# Altium schematic sheet LiDo_uC_Board_Controller.SchDoc
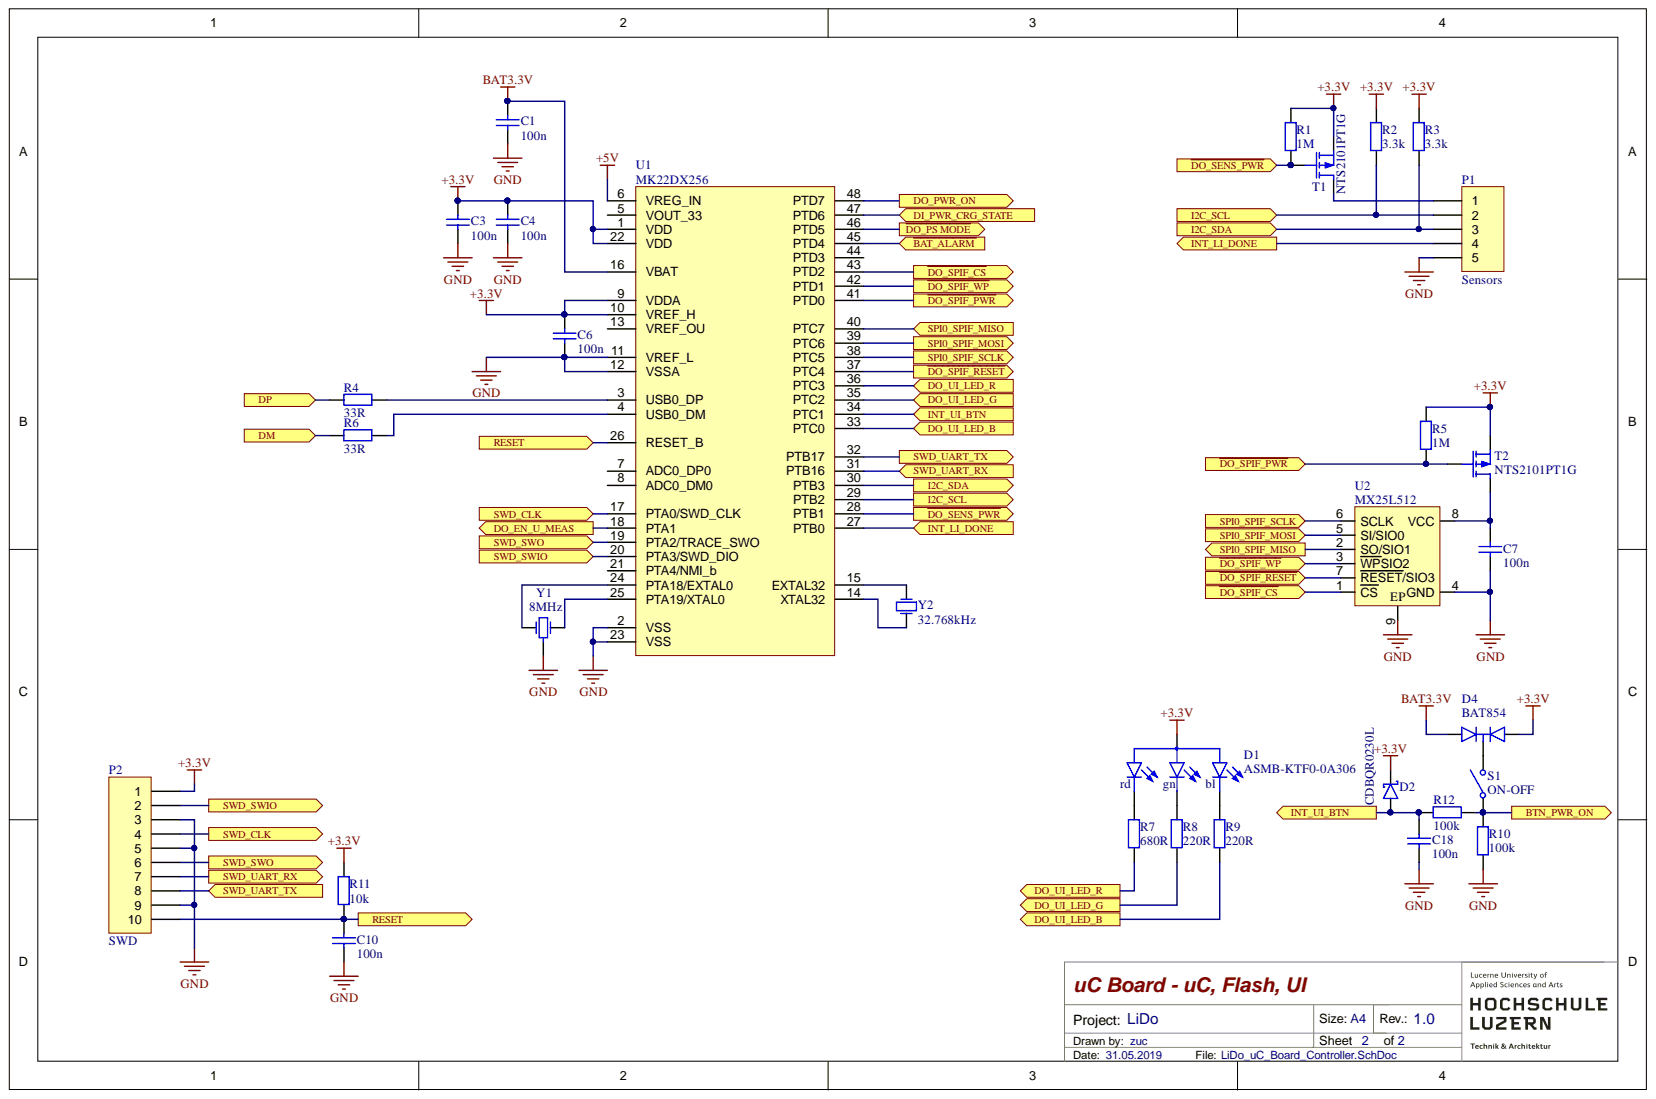
[diagram p0: LiDo_uC_Board_Controller.SchDoc]

## Parts
C1  100n  [0402S]
C3  100n  [0402S]
C4  100n  [0402S]
C6  100n  [0402S]
C7  100n  [0402S]
C10  100n  [0402S]
C18  100n  [0402S]
D1  ASMB-KTF0-0A306  [4PLCC]
D2  CDBQR0230L  [0402d]
D4  BAT854  [SOT-323]
P1  Sensors  [HDR1X5]
P2  SWD  [HEADER2X5_1.27S]
R1  1M  [0402S]
R2  3.3k  [0402S]
R3  3.3k  [0402S]
R4  33R  [0402S]
R5  1M  [0402S]
R6  33R  [0402S]
R7  680R  [0402S]
R8  220R  [0402S]
R9  220R  [0402S]
R10  100k  [0402S]
R11  10k  [0402S]
R12  100k  [0402S]
S1  ON-OFF  [TL3315]
T1  NTS2101PT1G  [SOT-323]
T2  NTS2101PT1G  [SOT-323]
U1  MK22DX256  [QFP50P700X700X160-48N]
U2  MX25L512  [WSON8L]
Y1  8MHz  [CSTCE-G15L]
Y2  32.768kHz  [ABS06]

## Ports
BTN_PWR_ON, DI_PWR_CRG_STATE, DM, DO_EN_U_MEAS, DO_PWR_ON, DO_UI_LED_B, DO_UI_LED_G, DO_UI_LED_R, DP, I2C_SCL, I2C_SDA, INT_LI_DONE, INT_UI_BTN, RESET, SPI0_SPIF_MISO, SPI0_SPIF_MOSI, SPI0_SPIF_SCLK, SWD_CLK, SWD_SWIO, SWD_SWO, SWD_UART_RX, SWD_UART_TX, ~{BAT_ALARM}, ~{DO_PS MODE}, ~{DO_SENS_PWR}, ~{DO_SPIF_CS}, ~{DO_SPIF_PWR}, ~{DO_SPIF_RESET}, ~{DO_SPIF_WP}

## Nets
+3.3V: C3.2 C4.2 C6.2 D1.1 D2.2 D4.1 P2.1 R1.2 R2.2 R3.2 R5.2 R11.2 T1.3 T2.3 U1.1(VDD) U1.9(VDDA) U1.10(VREF_H) U1.22(VDD)
+5V: U1.6(VREG_IN)
BAT3.3V: C1.2 D4.2 U1.16(VBAT)
GND: C1.1 C3.1 C4.1 C6.1 C7.1 C10.1 C18.1 P1.5 P2.3 P2.5 P2.9 R10.1 U1.2(VSS) U1.11(VREF_L) U1.12(VSSA) U1.23(VSS) U2.4(GND) U2.9(EP) Y1.2
~{BAT_ALARM}: U1.45(PTD4) ; port ~{BAT_ALARM}
BTN_PWR_ON: R10.2 R12.1 S1.1 ; port BTN_PWR_ON
~{DO_PS MODE}: U1.46(PTD5) ; port ~{DO_PS MODE}
~{DO_SENS_PWR}: R1.1 T1.2 U1.28(PTB1) ; port ~{DO_SENS_PWR}
~{DO_SPIF_CS}: U1.43(PTD2) U2.1(CS) ; port ~{DO_SPIF_CS}
~{DO_SPIF_PWR}: R5.1 T2.2 U1.41(PTD0) ; port ~{DO_SPIF_PWR}
~{DO_SPIF_RESET}: U1.37(PTC4) U2.7(RESET/SIO3) ; port ~{DO_SPIF_RESET}
~{DO_SPIF_WP}: U1.42(PTD1) U2.3(WPSIO2) ; port ~{DO_SPIF_WP}
DI_PWR_CRG_STATE: U1.47(PTD6) ; port DI_PWR_CRG_STATE
DM: R6.2 ; port DM
DO_EN_U_MEAS: U1.18(PTA1) ; port DO_EN_U_MEAS
DO_PWR_ON: U1.48(PTD7) ; port DO_PWR_ON
DO_UI_LED_B: R9.1 U1.33(PTC0) ; port DO_UI_LED_B
DO_UI_LED_G: R8.1 U1.35(PTC2) ; port DO_UI_LED_G
DO_UI_LED_R: R7.1 U1.36(PTC3) ; port DO_UI_LED_R
DP: R4.2 ; port DP
I2C_SCL: P1.2 R2.1 U1.29(PTB2) ; port I2C_SCL
I2C_SDA: P1.3 R3.1 U1.30(PTB3) ; port I2C_SDA
INT_LI_DONE: P1.4 U1.27(PTB0) ; port INT_LI_DONE
INT_UI_BTN: C18.2 D2.1 R12.2 U1.34(PTC1) ; port INT_UI_BTN
RESET: C10.2 P2.10 R11.1 U1.26(RESET_B) ; port RESET
SPI0_SPIF_MISO: U1.40(PTC7) U2.2(SO/SIO1) ; port SPI0_SPIF_MISO
SPI0_SPIF_MOSI: U1.39(PTC6) U2.5(SI/SIO0) ; port SPI0_SPIF_MOSI
SPI0_SPIF_SCLK: U1.38(PTC5) U2.6(SCLK) ; port SPI0_SPIF_SCLK
SWD_CLK: P2.4 U1.17(PTA0/SWD_CLK) ; port SWD_CLK
SWD_SWIO: P2.2 U1.20(PTA3/SWD_DIO) ; port SWD_SWIO
SWD_SWO: P2.6 U1.19(PTA2/TRACE_SWO) ; port SWD_SWO
SWD_UART_RX: P2.7 U1.31(PTB16) ; port SWD_UART_RX
SWD_UART_TX: P2.8 U1.32(PTB17) ; port SWD_UART_TX
NetC7_2: C7.2 T2.1 U2.8(VCC)
NetD1_2: D1.2 R7.2
NetD1_3: D1.3 R8.2
NetD1_4: D1.4 R9.2
NetD4_3: D4.3 S1.2
NetP1_1: P1.1 T1.1
NetR4_1: R4.1 U1.3(USB0_DP)
NetR6_1: R6.1 U1.4(USB0_DM)
NetU1_14: U1.14(XTAL32) Y2.2
NetU1_15: U1.15(EXTAL32) Y2.1
NetU1_24: U1.24(PTA18/EXTAL0) Y1.1
NetU1_25: U1.25(PTA19/XTAL0) Y1.3

Not connected: U1 5(VOUT_33) 7(ADC0_DP0) 8(ADC0_DM0) 13(VREF_OU) 21(PTA4/NMI_b) 44(PTD3)

## Library data of the parts
C1 C3 C4 C6 C7 C10 C18 = CapNP: Chip Capacitor SMD 0402 6.3V X6S 20%; Component Kind: Standard; Distributor: Digikey; Distributor Nr.: 490-9998-1-ND; Package: 0402; Value: 100n; Library Name: CCE.SCHLIB
D1 = LED_RGB_A: RGB LED mit gemeinsamer Anode; Component Kind: Standard; Distributor: Digikey; Distributor Nr.: 516-3906-1-ND; Package: 4PLCC; Value: ASMB-KTF0-0A306; Library Name: CCE.SCHLIB
D2 = DiodeSchottky: DIODE SCHOTTKY 30V 200MA 0402; Component Kind: Standard; Distributor: Digikey; Distributor Nr.: 641-1275-1-ND; Package: 0402; Value: CDBQR0230L; Library Name: CCE.SCHLIB
D4 = BAS40-05: VISHAY SEMICONDUCTOR - BAS40-05 - DIODE, SMALL SIGNAL, 40V, SOT; Component Kind: Standard; Distributor: Digikey; Distributor Nr.: 1727-4826-1-ND; Package: SOT-323; Value: BAT854; Library Name: CCE.SCHLIB
P1 = Header 5: Header, 5-Pin; Component Kind: Standard; LatestRevisionDate: 17-Jul-2002; LatestRevisionNote: Re-released for DXP Platform.; Library Name: Miscellaneous Connectors.IntLib; Signal Integrity: Connector; Publisher: Altium Limited
P2 = Header 10: Header, 10-Pin; Component Kind: Standard; Distributor: Mouser; Distributor Nr.: 200-MTMS10502TD002; Library Name: Miscellaneous Connectors.IntLib; Signal Integrity: Connector; Value: SWD
R1-R12 = Res: Chip Resistor SMD 0402 0.1W 1%; Component Kind: Standard; Distributor: Digikey; Package: 0402; Library Name: CCE.SCHLIB
  R1 R5: Value: 1M
  R2 R3: Distributor Nr.: P3.30KLCT-ND; Value: 3.3k
  R4 R6: Value: 33R
  R7: Value: 680R
  R8 R9: Value: 220R
  R10 R12: Value: 100k
  R11: Value: 10k
S1 = SW-SPST: Single-Pole, Single-Throw Switch  evt TL3215; Component Kind: Standard; Distributor: Digikey; Distributor Nr.: EG4620CT-ND; Value: ON-OFF; Library Name: CCE.SCHLIB
T1 T2 = MOSFET-P: P-Kanal MOSFET 30V 4,3 A (Ta) 1,38 W (Ta)SOT-23; Component Kind: Standard; Distributor: Digikey; Distributor Nr.: NTS2101PT1GOSCT-ND; Package: SC-70; Value: NTS2101PT1G; Library Name: CCE.SCHLIB
U1 = MK22DX256V: ARM Mikrocontroller - MCU KINETIS 256K FLEX; Component Kind: Standard; Distributor: Mouser; Distributor Nr.: 841-MK22DX256VLF5; Value: MK22DX256; Library Name: CCE.SCHLIB
U2 = MX25L51245GZ2I-08G: FLASH - NOR Speicher-IC 512 Mb (64 M x 8) SPI 166MHz 8-WSON (8x6); Component Kind: Standard; Distributor: Digikey; Distributor Nr.: MX25L51245GZ2I-08G; Package: WSON8L; Value: MX25L512; Library Name: LIDO.SchLib
Y1 = XTAL3: Resonatoren 8.0000MHz 33pF SMD CHP Rsntr 0.07% AUTO; Component Kind: Standard; Distributor: Mouser; Distributor Nr.: 81-CSTNE8M00GH5C000R; Value: 8MHz; Library Name: CCE.SCHLIB
Y2 = XTAL: SMD Low ESR Crystal 36.726kHz; Component Kind: Standard; Distributor: Mouser; Distributor Nr.: 815-ABS06-32.768K-T; Package: 2x1.2mm; Value: 32.768kHz; Library Name: CCE.SCHLIB
